# Altium schematic sheet DCPower.SchDoc(DCPower)
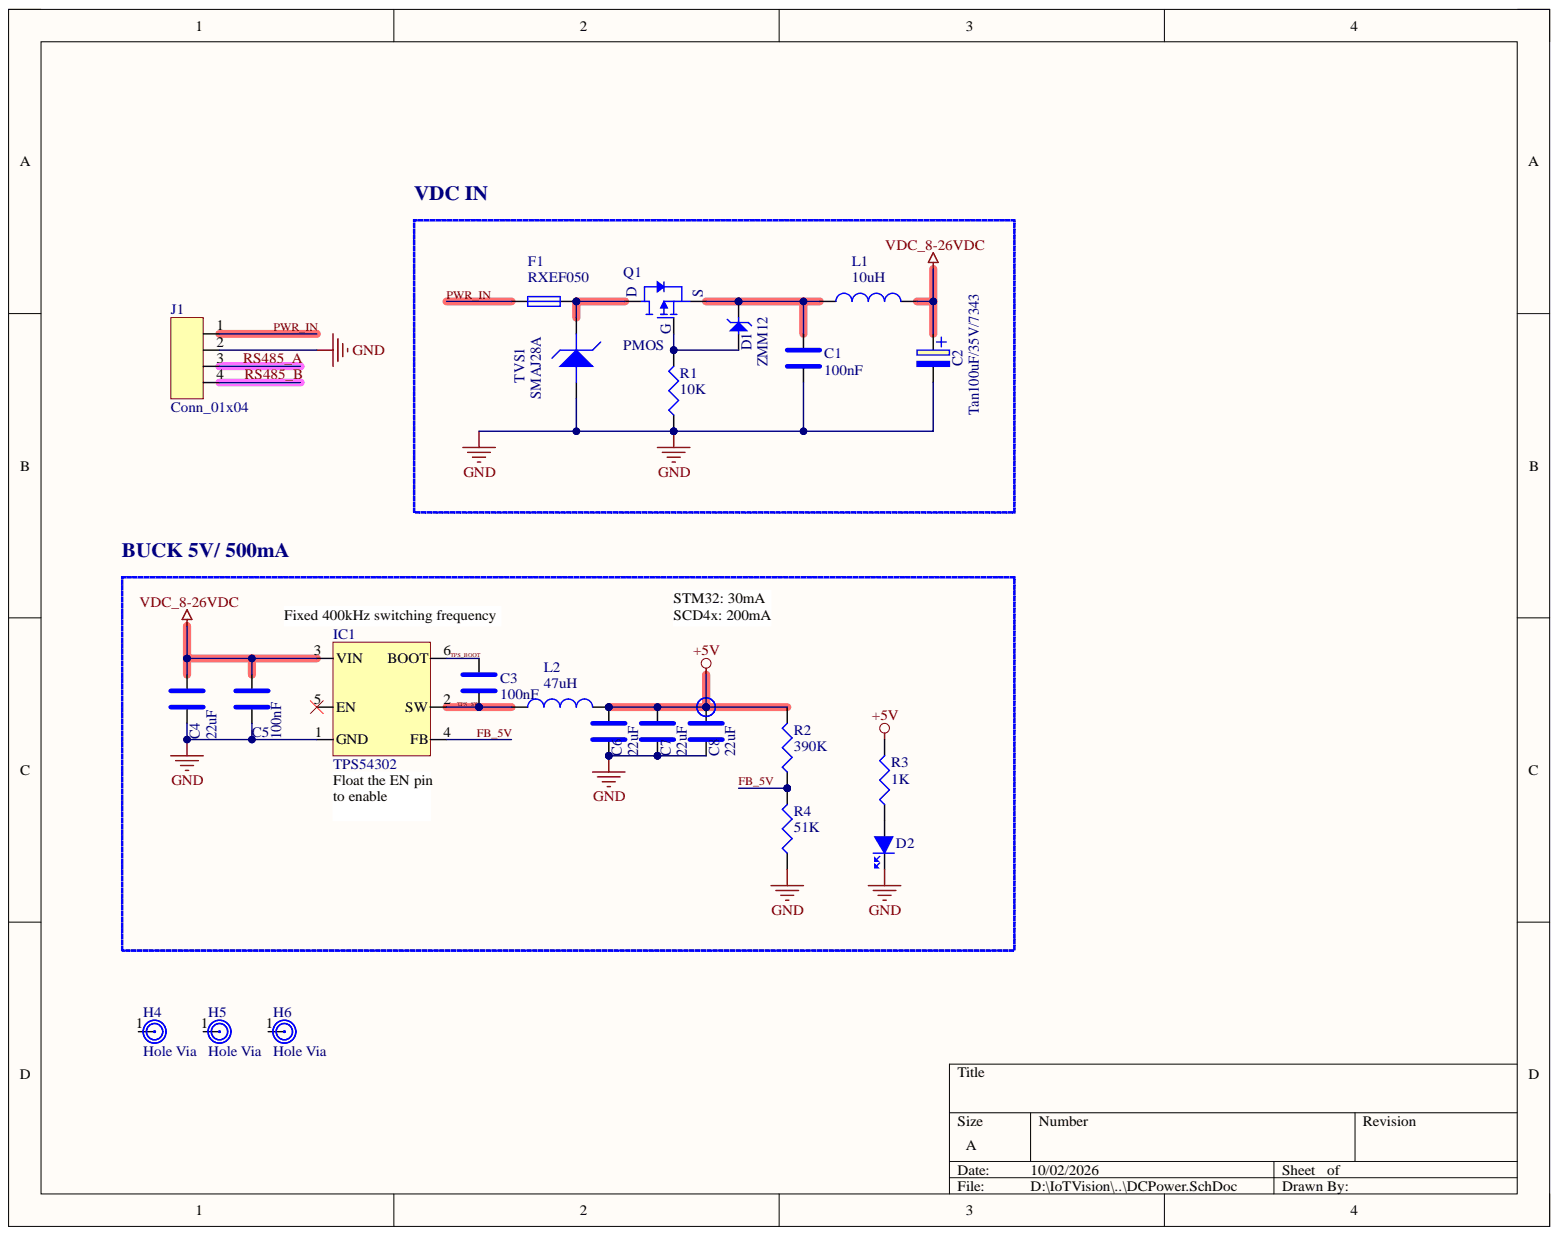
[diagram p0: DCPower.SchDoc]

## Parts
C1  100nF  [C_0603]
C2  Tan100uF/35V/7343  [CP_TANTALUM_EIA_D_7343]
C3  100nF  [C_0603]
C4  22uF  [C_0603]
C5  100nF  [C_0603]
C6  22uF  [C_0603]
C7  22uF  [C_0603]
C8  22uF  [C_0603]
D1  ZMM12  [SOD-80C_Glass MiniMELF]
D2  RedLed  [LED_0603]  (value not drawn)
F1  RXEF050  [FUSE_0805_2.2x1.5mm]
H4  Hole Via  [MountingHole_3.2mm_M3_Pad_Via]
H5  Hole Via  [MountingHole_3.2mm_M3_Pad_Via]
H6  Hole Via  [MountingHole_3.2mm_M3_Pad_Via]
IC1  TPS54302  [SOT-23-6]
J1  Conn_01x04  [TERMBLOCK_4P_3.81mm_Horizontal]
L1  10uH  [L_0630_7x7mm]
L2  47uH  [L_0630_7x7mm]
Q1  PMOS  [TO-252-2]
R1  10K  [R_0603]
R2  390K  [R_0603]
R3  1K  [R_0603]
R4  51K  [R_0603]
TP2  TestPoint_1MM  [TestPoint_1MM]  (value not drawn)
TVS1  SMAJ28A  [D_SMA]

## Nets
+5V: C6.2 C7.2 C8.2 L2.2 R2.2 R3.2 TP2.1
GND: C1.2 C2.2 C4.2 C5.2 C6.1 C7.1 C8.1 D2.K IC1.1(GND) J1.2 R1.1 R4.1 TVS1.A
VDC_8-26VDC: C2.1 C4.1 C5.1 IC1.3(VIN) L1.2
FB_5V: IC1.4(FB) R2.1 R4.2
PWR_IN: F1.1 J1.1
RS485_A: J1.3
RS485_B: J1.4
TPS_BOOT: C3.2 IC1.6(BOOT)
TPS_SW: C3.1 IC1.2(SW) L2.1
NetC1_1: C1.1 D1.K L1.1 Q1.2
NetD1_A: D1.A Q1.1 R1.2
NetD2_A: D2.A R3.1
NetF1_2: F1.2 Q1.3 TVS1.K

Not connected: H4 1; H5 1; H6 1; IC1 5(EN)

## Library data of the parts
C1 C3-C8 = C: Component Kind: Standard; Description: C; Library Name: LNDB_Schlib.SchLib
C2 = Cp: Component Kind: Standard; Description: Cp; Library Name: LNDB_Schlib.SchLib
D1 = D_Zener: Diode Zener; Component Kind: Standard; Library Name: LNDB_Schlib.SchLib
D2 = LED: Component Kind: Standard; Description: LED; Library Name: LNDB_Schlib.SchLib
F1 = Fuse: Component Kind: Standard; Description: Fuse; Library Name: LNDB_Schlib.SchLib
H4-H6 = Hole: Component Kind: Standard; Library Name: LNDB_Schlib.SchLib
IC1 = TPS54302: Buck Switching Regulator IC,Output 3A SOT-23-6; Component Kind: Standard; Library Name: LNDB_Schlib.SchLib
L1 L2 = L: Inductor; Component Kind: Standard; Library Name: LNDB_Schlib.SchLib
Q1 = MOSFET_P: MOSFET P; Component Kind: Standard; Library Name: LNDB_Schlib.SchLib
R1-R4 = R: Component Kind: Standard; Description: R; Library Name: LNDB_Schlib.SchLib
TVS1 = TVS_D_Unidirectional: TVS_D; Component Kind: Standard; Library Name: LNDB_Schlib.SchLib
J1 = Conn_01x04: Component Kind: Standard; Description: Conn_01x04; Library Name: LNDB_Schlib.SchLib
TP2 = TestPoint_1MM: Component Kind: Standard; Library Name: UY_Schlib.SchLib
